# Altium schematic sheet Pmod_SWCAN.SchDoc(Pmod_SWCAN)
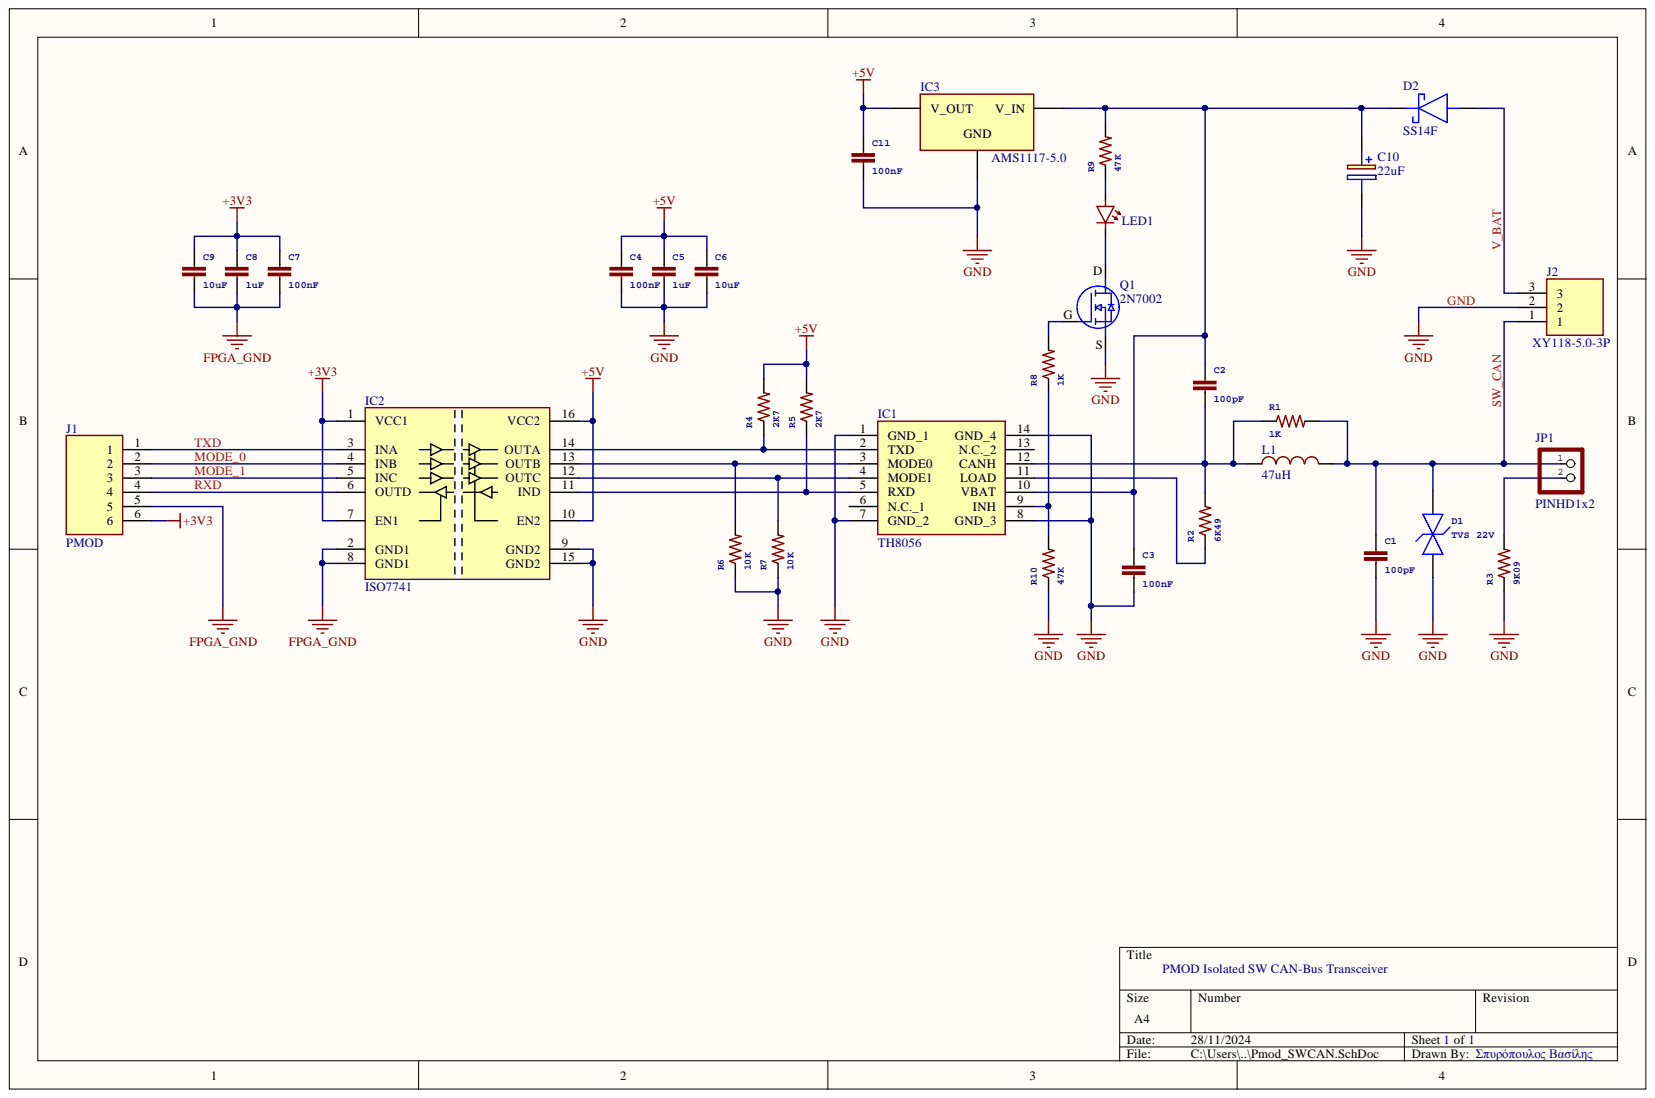
[diagram p0: Pmod_SWCAN.SchDoc]

## Parts
C1  100pF  [C0805]
C2  100pF  [C0805]
C3  100nF  [C0805]
C4  100nF  [C0603]
C5  1uF  [C0805]
C6  10uF  [C0805]
C7  100nF  [C0603]
C8  1uF  [C0805]
C9  10uF  [C0805]
C10  22uF  [CAPPM3528X210N]
C11  100nF  [C0805]
D1  TVS 22V  [SOT95P230X110-3N]
D2  SS14F  [SODFL4626X110N]
IC1  TH8056  [SOIC127P600X172-14N]
IC2  ISO7741  [SOIC127P1030X265-16N]
IC3  AMS1117-5.0  [SOT229P700X180-4N_tab_pin2]
J1  PMOD  [HDRRA6W64P0X254_1X6_1524X254X264P]
J2  XY118-5.0-3P  [XY118-5.0-3P]
JP1  PINHD1x2  [PINHD1x2]
L1  47uH  [L2317mm]
LED1  LED_0805  [LEDC2012X90N]  (value not drawn)
Q1  2N7002  [SOT95P230X110-3N]
R1  1K  [R0805]
R2  6K49  [R1210]
R3  9K09  [R2512]
R4  2K7  [R0805]
R5  2K7  [R0805]
R6  10K  [R0805]
R7  10K  [R0805]
R8  1K  [R0805]
R9  47K  [R0805]
R10  47K  [R0805]

## Nets
+3V3: C7.1 C8.1 C9.1 IC2.1(VCC1) IC2.7(EN1) J1.6
+5V: C4.1 C5.1 C6.1 C11.1 IC2.10(EN2) IC2.16(VCC2) IC3.2 R4.2 R5.2
FPGA_GND: C7.2 C8.2 C9.2 IC2.2(GND1) IC2.8(GND1) J1.5
GND: C1.2 C3.2 C4.2 C5.2 C6.2 C10.2 C11.2 D1.2 IC1.1(GND_1) IC1.7(GND_2) IC1.8(GND_3) IC1.14(GND_4) IC2.9(GND2) IC2.15(GND2) IC3.3 J2.2 Q1.S R3.1 R6.1 R7.1 R10.1
MODE_0: IC2.4(INB) J1.2
MODE_1: IC2.5(INC) J1.3
RXD: IC2.6(OUTD) J1.4
SW_CAN: C1.1 D1.1 J2.1 JP1.1 L1.2 R1.2
TXD: IC2.3(INA) J1.1
V_BAT: D2.2 J2.3
NetC2_1: C2.1 C3.1 C10.1 D2.1 IC1.10(VBAT) IC3.1 R9.2
NetC2_2: C2.2 IC1.12(CANH) L1.1 R1.1 R2.2
NetIC1_2: IC1.2(TXD) IC2.14(OUTA) R4.1
NetIC1_3: IC1.3(MODE0) IC2.13(OUTB) R6.2
NetIC1_4: IC1.4(MODE1) IC2.12(OUTC) R7.2
NetIC1_5: IC1.5(RXD) IC2.11(IND) R5.1
NetIC1_9: IC1.9(INH) R8.1 R10.2
NetIC1_11: IC1.11(LOAD) R2.1
NetJP1_2: JP1.2 R3.2
NetLED1_1: LED1.1 Q1.D
NetLED1_2: LED1.2 R9.1
NetQ1_G: Q1.G R8.2

Not connected: IC1 6(N.C._1) 13(N.C._2)

## Library data of the parts
C1-C3 C5 C6 C8 C9 C11 = C-EUC0805: Library Name: SamacSys.SchLib; Component Kind: Standard
C4 C7 = C-EUC0603: Library Name: SamacSys.SchLib; Component Kind: Standard
C10 = TPSB226K020T0600: Capacitor Polarised; Manufacturer_Name: AVX; Mouser Price/Stock: https://www.mouser.co.uk/ProductDetail/AVX/TPSB226K020T0600?qs=l7cgNqFNU1htdPexmfIHzQ%3D%3D; Mouser Part Number: 581-TPSB226K020T0600; Manufacturer_Part_Number: TPSB226K020T0600; Library Name: SamacSys.SchLib; Height: 2.1mm; Datasheet Link: https://componentsearchengine.com/Datasheets/1/TPSB226K020T0600.pdf; Component Kind: Standard; Arrow Price/Stock: https://www.arrow.com/en/products/tpsb226k020t0600/avx; Arrow Part Number: TPSB226K020T0600
D1 = MMBZ27VCL: TVS Diode (Uni-directional); Manufacturer_Part_Number: MMBZ27VCL,215; Manufacturer_Name: Nexperia; Mouser Price/Stock: https://www.mouser.co.uk/ProductDetail/Nexperia/MMBZ27VCL215?qs=mSipnS5lFm85%2FX3V730hbQ%3D%3D; Mouser Part Number: 771-MMBZ27VCL,215; Library Name: SamacSys.SchLib; Height: 1.1mm; Datasheet Link: https://assets.nexperia.com/documents/data-sheet/MMBZXVCL_MMBZXVDL_SER.pdf; Component Kind: Standard; Arrow Price/Stock: https://www.arrow.com/en/products/mmbz27vcl215/nexperia; Arrow Part Number: MMBZ27VCL,215
D2 = SS14F: Schottky Diode; Manufacturer_Name: Shikues; Manufacturer_Part_Number: SS14F; Library Name: SamacSys.SchLib; Height: 1.1mm; Datasheet Link: https://datasheet.lcsc.com/lcsc/1811021114_Shikues-SS14F_C110213.pdf; Component Kind: Standard
IC1 = TH8056KDC-AAA-014-SP: Integrated Circuit; Manufacturer_Name: Melexis; Mouser Price/Stock: https://www.mouser.co.uk/ProductDetail/Melexis/TH8056KDC-AAA-014-SP?qs=KuGPmAKtFKViuyWpDi5meQ%3D%3D; Mouser Part Number: 482-8056KDCAAA014SP; Manufacturer_Part_Number: TH8056KDC-AAA-014-SP; Height: 1.72mm; Datasheet Link: https://componentsearchengine.com/Datasheets/1/TH8056KDC-AAA-014-SP.pdf; Component Kind: Standard
IC2 = ISO7741QDWRQ1: Integrated Circuit; Manufacturer_Name: Texas Instruments; Mouser Price/Stock: https://www.mouser.co.uk/ProductDetail/Texas-Instruments/ISO7741QDWRQ1?qs=34RfhUjJmKdiyQ9qp4sakQ%3D%3D; Mouser Part Number: 595-ISO7741QDWRQ1; Manufacturer_Part_Number: ISO7741QDWRQ1; Library Name: SamacSys.SchLib; Height: 2.65mm; Datasheet Link: http://www.ti.com/lit/gpn/ISO7741-Q1; Component Kind: Standard; Arrow Price/Stock: https://www.arrow.com/en/products/iso7741qdwrq1/texas-instruments?region=nac; Arrow Part Number: ISO7741QDWRQ1
IC3 = AMS1117-5.0: Integrated Circuit; Manufacturer_Name: Advanced Monolithic Systems; Manufacturer_Part_Number: AMS1117-5.0; Library Name: SamacSys.SchLib; Height: 1.8mm; Datasheet Link: http://www.advanced-monolithic.com/pdf/ds1117.pdf; Component Kind: Standard
J1 = M20-9750642: Connector; Manufacturer_Name: Harwin; Mouser Price/Stock: https://www.mouser.co.uk/ProductDetail/Harwin/M20-9750642?qs=WS5Jv%252B%252Bx1qV0gjh6kO4SeA%3D%3D; Mouser Part Number: 855-M20-9750642; Manufacturer_Part_Number: M20-9750642; Library Name: SamacSys.SchLib; Height: 2.64mm; Datasheet Link: https://cdn.harwin.com/pdfs/M20-975.pdf; Component Kind: Standard
J2 = XY118-5.0-3P: Connector; Manufacturer_Part_Number: 1752227; Manufacturer_Name: Phoenix Contact; Mouser Price/Stock: https://www.mouser.co.uk/ProductDetail/Phoenix-Contact/1752227?qs=Fz3yxlUjJZHEnstsy1zDVg%3D%3D; Mouser Part Number: 651-1752227; Library Name: SamacSys.SchLib; Height: 12.55mm; Datasheet Link: https://www.phoenixcontact.com/online/portal/ae/pxc/product_detail_page/!ut/p/z1/3VdNb-IwEP0rXLitsfPhJBxpQEtZClSEXZJLZCcGuc0XiUtKf_06kKqF3YJWiD0kGim24_fGb-yJxtCDS-glZMvXRPA0IZHsu57hq98VpAx15WFqPpro8W5sO8ZcV6ZDA_6EHvSyPA1fAnEfQlcxsaqqZjUqCJX9qhVxmpN8B13CWF; Component Kind: Standard; Arrow Price/Stock: https://www.arrow.com/en/products/1752227/phoenix-contact; Arrow Part Number: 1752227
L1 = L-0805: Library Name: SamacSys.SchLib; Component Kind: Standard
LED1 = LED_0805: LED; Manufacturer_Part_Number: LTST-C171GKT; Manufacturer_Name: Lite-On; Mouser Price/Stock: https://www.mouser.com/Search/Refine.aspx?Keyword=859-LTST-C171GKT; Mouser Part Number: 859-LTST-C171GKT; Library Name: SamacSys.SchLib; Height: 0.9mm; Datasheet Link: https://componentsearchengine.com/Datasheets/1/LTST-C171GKT.pdf; Component Kind: Standard
Q1 = 2N7002NXAKR: MOSFET (N-Channel); Manufacturer_Name: Nexperia; Manufacturer_Part_Number: 2N7002NXAKR; Library Name: SamacSys.SchLib; Height: 1.1mm; Component Kind: Standard
R1 R4-R10 = R-US_R0805: Library Name: SamacSys.SchLib; Component Kind: Standard
R2 = R-US_R1210: Library Name: SamacSys.SchLib; Component Kind: Standard
R3 = R-US_R2512: Library Name: SamacSys.SchLib; Component Kind: Standard
JP1 = PINHD1x2: Library Name: SamacSys.SchLib; Component Kind: Standard
